# Altium schematic sheet AMS_Slave_Balancing.SchDoc
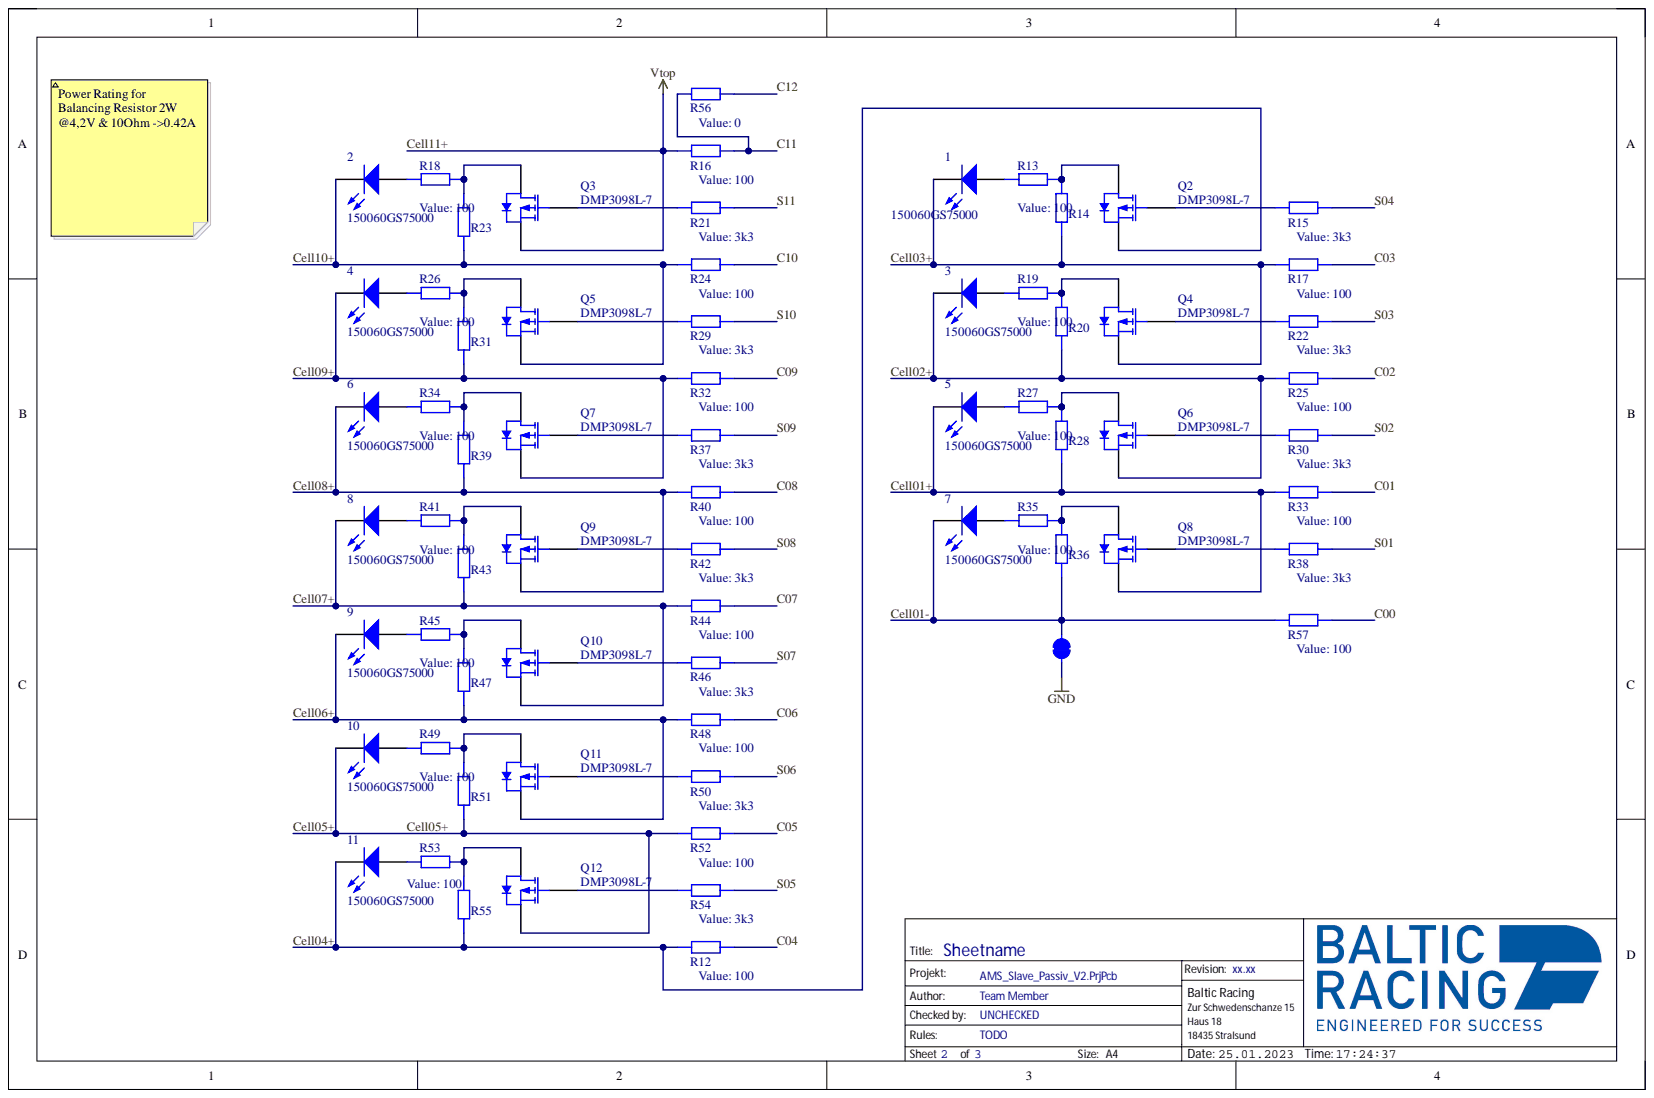
[diagram p0: AMS_Slave_Balancing.SchDoc]

## Parts
1  150060GS75000  [0603_A]
2  150060GS75000  [0603_A]
3  150060GS75000  [0603_A]
4  150060GS75000  [0603_A]
5  150060GS75000  [0603_A]
6  150060GS75000  [0603_A]
7  150060GS75000  [0603_A]
8  150060GS75000  [0603_A]
9  150060GS75000  [0603_A]
10  150060GS75000  [0603_A]
11  150060GS75000  [0603_A]
J5  SMD Jumper closed  [SMD-JUMPER-CLOSED]  (value not drawn)
Q2  DMP3098L-7  [DIOD-SOT23-3_V]
Q3  DMP3098L-7  [DIOD-SOT23-3_V]
Q4  DMP3098L-7  [DIOD-SOT23-3_V]
Q5  DMP3098L-7  [DIOD-SOT23-3_V]
Q6  DMP3098L-7  [DIOD-SOT23-3_V]
Q7  DMP3098L-7  [DIOD-SOT23-3_V]
Q8  DMP3098L-7  [DIOD-SOT23-3_V]
Q9  DMP3098L-7  [DIOD-SOT23-3_V]
Q10  DMP3098L-7  [DIOD-SOT23-3_V]
Q11  DMP3098L-7  [DIOD-SOT23-3_V]
Q12  DMP3098L-7  [DIOD-SOT23-3_V]
R12  Value  value 100  [STACKPOLE CSR0603]
R13  SMD Resistor  [STACKPOLE CSR0603]  (value not drawn)
R14  SMD Resistor  [STACKPOLE CSRN2512]  (value not drawn)
R15  value 3k3  [STACKPOLE CSR0603]  (hidden comment: SMD Resistor)
R16  Value  value 100  [STACKPOLE CSR0603]
R17  Value  value 100  [STACKPOLE CSR0603]
R18  SMD Resistor  [STACKPOLE CSR0603]  (value not drawn)
R19  SMD Resistor  [STACKPOLE CSR0603]  (value not drawn)
R20  SMD Resistor  [STACKPOLE CSRN2512]  (value not drawn)
R21  value 3k3  [STACKPOLE CSR0603]  (hidden comment: SMD Resistor)
R22  value 3k3  [STACKPOLE CSR0603]  (hidden comment: SMD Resistor)
R23  SMD Resistor  [STACKPOLE CSRN2512]  (value not drawn)
R24  Value  value 100  [STACKPOLE CSR0603]
R25  Value  value 100  [STACKPOLE CSR0603]
R26  SMD Resistor  [STACKPOLE CSR0603]  (value not drawn)
R27  value 100  [STACKPOLE CSR0603]  (hidden comment: SMD Resistor)
R28  SMD Resistor  [STACKPOLE CSRN2512]  (value not drawn)
R29  value 3k3  [STACKPOLE CSR0603]  (hidden comment: SMD Resistor)
R30  value 3k3  [STACKPOLE CSR0603]  (hidden comment: SMD Resistor)
R31  SMD Resistor  [STACKPOLE CSRN2512]  (value not drawn)
R32  Value  value 100  [STACKPOLE CSR0603]
R33  Value  value 100  [STACKPOLE CSR0603]
R34  value 100  [STACKPOLE CSR0603]  (hidden comment: SMD Resistor)
R35  SMD Resistor  [STACKPOLE CSR0603]  (value not drawn)
R36  SMD Resistor  [STACKPOLE CSRN2512]  (value not drawn)
R37  value 3k3  [STACKPOLE CSR0603]  (hidden comment: SMD Resistor)
R38  value 3k3  [STACKPOLE CSR0603]  (hidden comment: SMD Resistor)
R39  SMD Resistor  [STACKPOLE CSRN2512]  (value not drawn)
R40  Value  value 100  [STACKPOLE CSR0603]
R41  SMD Resistor  [STACKPOLE CSR0603]  (value not drawn)
R42  value 3k3  [STACKPOLE CSR0603]  (hidden comment: SMD Resistor)
R43  SMD Resistor  [STACKPOLE CSRN2512]  (value not drawn)
R44  Value  value 100  [STACKPOLE CSR0603]
R45  value 100  [STACKPOLE CSR0603]  (hidden comment: SMD Resistor)
R46  value 3k3  [STACKPOLE CSR0603]  (hidden comment: SMD Resistor)
R47  SMD Resistor  [STACKPOLE CSRN2512]  (value not drawn)
R48  Value  value 100  [STACKPOLE CSR0603]
R49  value 100  [STACKPOLE CSR0603]  (hidden comment: SMD Resistor)
R50  value 3k3  [STACKPOLE CSR0603]  (hidden comment: SMD Resistor)
R51  SMD Resistor  [STACKPOLE CSRN2512]  (value not drawn)
R52  Value  value 100  [STACKPOLE CSR0603]
R53  value 100  [STACKPOLE CSR0603]  (hidden comment: SMD Resistor)
R54  value 3k3  [STACKPOLE CSR0603]  (hidden comment: SMD Resistor)
R55  SMD Resistor  [STACKPOLE CSRN2512]  (value not drawn)
R56  Value  [STACKPOLE CSR0603]
R57  Value  value 100  [STACKPOLE CSR0603]

## Nets
GND: J5.1
C00: R57.2
C01: R33.2
C02: R25.2
C03: R17.2
C04: R12.2
C05: R52.2
C06: R48.2
C07: R44.2
C08: R40.2
C09: R32.2
C10: R24.2
C11: R16.2 R56.1
C12: R56.2
Cell01+: 5.1 Q8.2 R28.1 R33.1
Cell01-: 7.1 J5.2 R36.1 R57.1
Cell02+: 3.1 Q6.2 R20.1 R25.1
Cell03+: 1.1 Q4.2 R14.1 R17.1
Cell04+: 11.1 Q2.2 R12.1 R55.1
Cell05+: 10.1 Q12.2 R51.1 R52.1
Cell06+: 9.1 Q11.2 R47.1 R48.1
Cell07+: 8.1 Q10.2 R43.1 R44.1
Cell08+: 6.1 Q9.2 R39.1 R40.1
Cell09+: 4.1 Q7.2 R31.1 R32.1
Cell10+: 2.1 Q5.2 R23.1 R24.1
Cell11+: Q3.2 R16.1
S01: R38.2
S02: R30.2
S03: R22.2
S04: R15.2
S05: R54.2
S06: R50.2
S07: R46.2
S08: R42.2
S09: R37.2
S10: R29.2
S11: R21.2
Net1_2: 1.2 R13.2
Net2_2: 2.2 R18.2
Net3_2: 3.2 R19.2
Net4_2: 4.2 R26.2
Net5_2: 5.2 R27.2
Net6_2: 6.2 R34.2
Net7_2: 7.2 R35.2
Net8_2: 8.2 R41.2
Net9_2: 9.2 R45.2
Net10_2: 10.2 R49.2
Net11_2: 11.2 R53.2
NetQ2_1: Q2.1 R15.1
NetQ2_3: Q2.3 R13.1 R14.2
NetQ3_1: Q3.1 R21.1
NetQ3_3: Q3.3 R18.1 R23.2
NetQ4_1: Q4.1 R22.1
NetQ4_3: Q4.3 R19.1 R20.2
NetQ5_1: Q5.1 R29.1
NetQ5_3: Q5.3 R26.1 R31.2
NetQ6_1: Q6.1 R30.1
NetQ6_3: Q6.3 R27.1 R28.2
NetQ7_1: Q7.1 R37.1
NetQ7_3: Q7.3 R34.1 R39.2
NetQ8_1: Q8.1 R38.1
NetQ8_3: Q8.3 R35.1 R36.2
NetQ9_1: Q9.1 R42.1
NetQ9_3: Q9.3 R41.1 R43.2
NetQ10_1: Q10.1 R46.1
NetQ10_3: Q10.3 R45.1 R47.2
NetQ11_1: Q11.1 R50.1
NetQ11_3: Q11.3 R49.1 R51.2
NetQ12_1: Q12.1 R54.1
NetQ12_3: Q12.3 R53.1 R55.2

## Library data of the parts
1-11 = CMP-1426-00002-1: SMD mono-color Chip LED, WL-SMCW, Green; Manufacturer: Wuerth Elektronik; Color: Green; Component Kind: Standard; ComponentLink1Description: Manufacturer URL; ComponentLink1URL: http://www.we-online.com; ComponentLink2Description: Datasheet; ComponentLink2URL: http://katalog.we-online.com/led/datasheet/150060GS75000.pdf; Library Name: Altium Content Vault; PackageReference: 0603_A; PartNumber: 150060GS75000
Q2-Q12 = CMP-0697-00001-2: P-Channel Enhancement Mode MOSFET, -30 V VDS, -55 to 155 degC, 3-Pin SOT23, RoHS, Tape and Reel; Manufacturer: Diodes Inc; IDS (TA: 25 degC)=-3.8 A; PD (TA: 25 degC)=1.08 W; RDS(on) (Max.) (VGS: 4.5 V)=120 mOhm; VDS: -30 V; VGS: +/- 20 V; VGS(th) (Max.): -2.1 V; Component Kind: Standard; ComponentLink1Description: Manufacturer URL; ComponentLink1URL: http://www.diodes.com/; ComponentLink2Description: Datasheet; ComponentLink2URL: http://www.diodes.com/datasheets/ds31447.pdf; ComponentLink3Description: Package Specification; ComponentLink3URL: http://www.diodes.com/datasheets/ap02002.pdf; DatasheetVersion: Rev. 8 - 2; Library Name: Altium Content Vault; Mounting Technology: Surface Mount; Operating Temperature Range: -55 to 150 degC; PackageDescription: 3-Pin Small Outline Transistor, Body 1.3 x 2.9 mm, Pitch 0.95 mm; PackageReference: SOT23; PackageVersion: Rev. 75; Packing: Tape and Reel; PartNumber: DMP3098L-7; RoHS: TRUE
R12 R16 R17 R24 R25 R30 R32 R33 R37 R38 R40 R42 R44 R46 R48 R50 R52 R54 R57 = SMD Resistor: Resistor with multiple footprints; Component Kind: Standard; Library Name: Standardbauteile.IntLib
R13 R18 R19 R26 R27 R34 R35 R41 R45 R49 R53 = SMD Resistor: Resistor with multiple footprints; Value: 100; Component Kind: Standard; Library Name: Standardbauteile.IntLib
R14 R20 R23 R28 R31 R36 R39 R43 R47 R51 R55 = SMD Resistor: Resistor with multiple footprints; Value: 10; Component Kind: Standard; Library Name: Standardbauteile.IntLib
R15 R21 R22 R29 = SMD Resistor: Resistor with multiple footprints; Value: 3k3; Component Kind: Standard; Library Name: Standardbauteile.IntLib
R56 = SMD Resistor: Resistor with multiple footprints; Value: 0; Component Kind: Standard; Library Name: Standardbauteile.IntLib
J5 = SMD Jumper closed: Component Kind: Net Tie; Library Name: Standardbauteile.IntLib
